# Altium schematic sheet SPI LVDS Peripheral.SchDoc("SPI LVDS Peripheral")
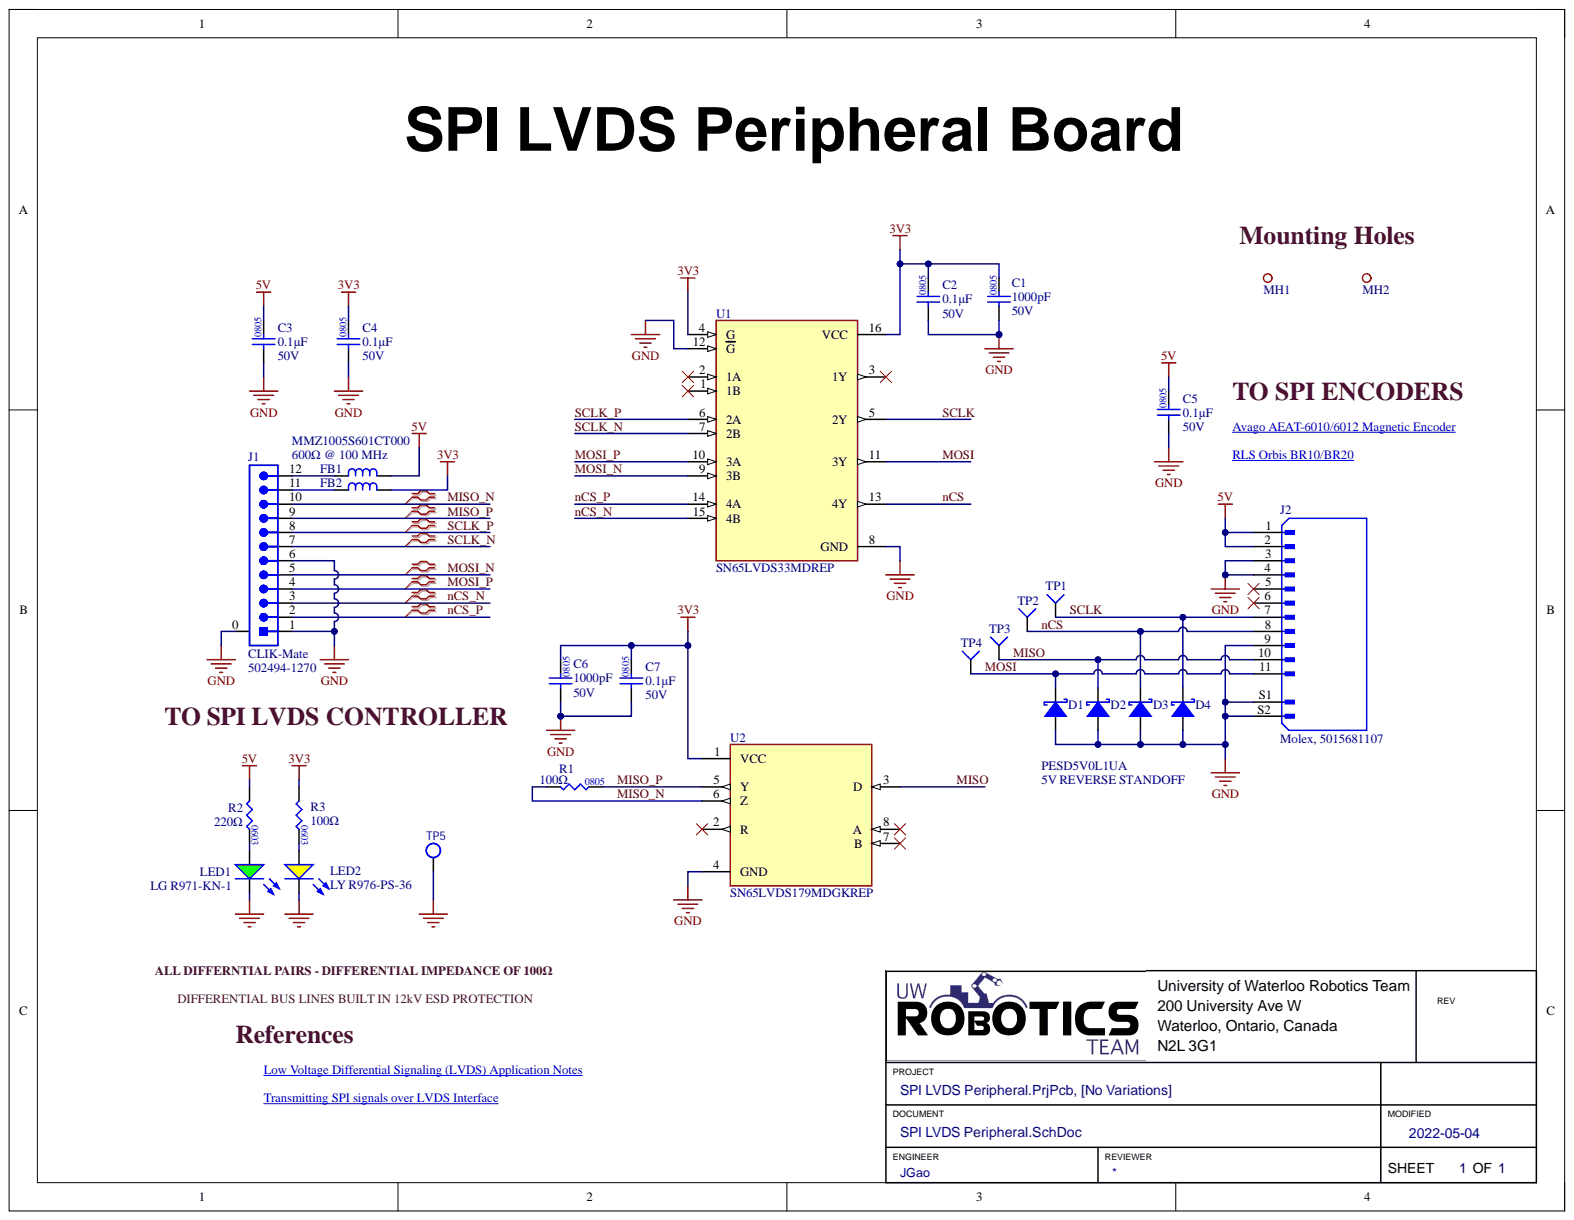
[diagram p0: SPI LVDS Peripheral.SchDoc]

## Parts
C1  value 1000pF  Package/Footprint: 0805  Voltage Rating: 50V  [CAP2012]  (hidden comment: CAP_1000pF_50V_0805)
C2  value 0.1μF  Package/Footprint: 0805  Voltage Rating: 50V  [CAP2012]  (hidden comment: CAP_0.1uF_50V_0805)
C3  value 0.1μF  Package/Footprint: 0805  Voltage Rating: 50V  [CAP2012]  (hidden comment: CAP_0.1uF_50V_0805)
C4  value 0.1μF  Package/Footprint: 0805  Voltage Rating: 50V  [CAP2012]  (hidden comment: CAP_0.1uF_50V_0805)
C5  value 0.1μF  Package/Footprint: 0805  Voltage Rating: 50V  [CAP2012]  (hidden comment: CAP_0.1uF_50V_0805)
C6  value 1000pF  Package/Footprint: 0805  Voltage Rating: 50V  [CAP2012]  (hidden comment: CAP_1000pF_50V_0805)
C7  value 0.1μF  Package/Footprint: 0805  Voltage Rating: 50V  [CAP2012]  (hidden comment: CAP_0.1uF_50V_0805)
D1  TVS_DIODE_UNIDIR_5V  [SOD-323]  (value not drawn)
D2  TVS_DIODE_UNIDIR_5V  [SOD-323]  (value not drawn)
D3  TVS_DIODE_UNIDIR_5V  [SOD-323]  (value not drawn)
D4  TVS_DIODE_UNIDIR_5V  [SOD-323]  (value not drawn)
FB1  Impedance: 600Ω  Impedance @ Frequency: 600Ω @ 100 MHz  Manufacturer Part Number 1: MMZ1005S601CT000  [RES0402]  (hidden comment: FERRITE_BEAD_600OHM_0402)
FB2  FERRITE_BEAD_600OHM_0402  [RES0402]  (value not drawn)
J1  Family: CLIK-Mate  Manufacturer Part Number: 502494-1270  [MOLEX-5024941270]  (hidden comment: CON_12M_SM_RA_2mm)
J2  MP: Molex, 5015681107  [MOLEX-5015681107]  (hidden comment: CON_12M_SM_RA)
LED1  LED_GREEN  [LED_0805_GREEN]  (value not drawn)
LED2  Manufacturer Part Number 1: LY R976-PS-36  [LED_0805_YELLOW]  (hidden comment: LED_YELLOW)
MH1  MOUNTING_HOLE_M3  [MOUNTING_HOLE_3mm]  (value not drawn)  (no BOM)
MH2  MOUNTING_HOLE_M3  [MOUNTING_HOLE_3mm]  (value not drawn)  (no BOM)
R1  value 100Ω  Package/Footprint: 0805  [RES0805]  (hidden comment: RES_100Ω_0805_1%)
R2  value 220Ω  Package/Footprint: 0603  [RES0603]  (hidden comment: RES_220Ω_0603_5%)
R3  value 100Ω  [RES0603]  (hidden comment: RES_100Ω_0603_1%)
TP1  TESTPOINT_1MM_SQUARE  [TESTPOINT_SQUARE_1MM]  (value not drawn)  (no BOM)
TP2  TESTPOINT_1MM_SQUARE  [TESTPOINT_SQUARE_1MM]  (value not drawn)  (no BOM)
TP3  TESTPOINT_1MM_SQUARE  [TESTPOINT_SQUARE_1MM]  (value not drawn)  (no BOM)
TP4  TESTPOINT_1MM_SQUARE  [TESTPOINT_SQUARE_1MM]  (value not drawn)  (no BOM)
TP5  TESTPOINT_LOOP  [TESTPOINT_LOOP]  (value not drawn)
U1  SN65LVDS33MDREP  [SOIC127P600X175-16N]
U2  SN65LVDS179MDGKREP  [SOP65P490X110-8N]

## Nets
3V3: C1.2 C2.2 C4.2 C6.2 C7.2 FB2.1 R3.1 U1.4(G) U1.16(VCC) U2.1(VCC)
5V: C3.2 C5.2 FB1.1 J2.1 J2.2 R2.1
GND: C1.1 C2.1 C3.1 C4.1 C5.1 C6.1 C7.1 D1.1 D2.1 D3.1 D4.1 J1.0 J1.1 J1.6 J2.3 J2.4 J2.9 J2.S1 J2.S2 LED1.2 LED2.2 TP5.1 U1.8(GND) U1.12(G) U2.4(GND)
MISO: D2.2 J2.10 TP3.1 U2.3(D)
MISO_N: J1.10 R1.1 U2.6(Z)
MISO_P: J1.9 R1.2 U2.5(Y)
MOSI: D1.2 J2.11 TP4.1 U1.11(3Y)
MOSI_N: J1.5 U1.9(3B)
MOSI_P: J1.4 U1.10(3A)
nCS: D3.2 J2.8 TP2.1 U1.13(4Y)
nCS_N: J1.3 U1.15(4B)
nCS_P: J1.2 U1.14(4A)
SCLK: D4.2 J2.7 TP1.1 U1.5(2Y)
SCLK_N: J1.7 U1.7(2B)
SCLK_P: J1.8 U1.6(2A)
NetFB1_2: FB1.2 J1.12
NetFB2_2: FB2.2 J1.11
NetLED1_1: LED1.1 R2.2
NetLED2_1: LED2.1 R3.2

Not connected: J2 5 6; U1 1(1B) 2(1A) 3(1Y); U2 2(R) 7(B) 8(A)

## Library data of the parts
C1 C6 = CAP_1000pF_50V_0805: CAP CER 1000pF 50V X7R 0805; Manufacturer 1: Samsung; Manufacturer Part Number 1: CL21B102KBANNNC; Component Kind: Standard; Dielectric: X7R; Mounting Type: SMD; Supplier 1: Digi-Key; Supplier Part Number 1: 1276-1020-1-ND; Library Name: UWRT_Schematic_Library_2020.SchLib
C2-C5 C7 = CAP_0.1uF_50V_0805: CAP CER 0.1UF 50V X7R 0805; Manufacturer 1: KEMET; Manufacturer Part Number 1: C0805C104K5RAC7411; Component Kind: Standard; Dielectric: X7R; LCSC Part #: C49678; Mounting Type: SMD; Supplier 1: Mouser; Supplier Part Number 1: 80-C0805C104K5RACLR; Library Name: UWRT_Schematic_Library_2020.SchLib
D1-D4 = TVS_DIODE_UNIDIR_5V: TVS DIODE 5VWM 12VC SOD-323-2; Manufacturer 1: Nexperia; Manufacturer Part Number 1: PESD5V0L1UA; Clamping Voltage-Max: 12V; Min Breakdown Voltage: 6.8 V; Component Kind: Standard; Supplier 1: Mouser; Supplier Part Number 1: 771-PESD5V0L1UA115; Library Name: UWRT_Schematic_Library_2020.SchLib
FB1 = FERRITE_BEAD_600OHM_0402: FIXED IND 1UH 3.8A 33 MOHM SMD; Manufacturer 1: TDK Corporation; Current Rating (Max): 300mA; DC Resistance (DCR) (Max): 520mΩ; Component Kind: Standard; Mounting Type: SMD; Package: 0402; Package/Footprint: SMD; Supplier 1: Digi-Key; Supplier Part Number 1: 445-2162-1-ND; Library Name: UWRT_Schematic_Library_2020.SchLib
FB2 = FERRITE_BEAD_600OHM_0402: FIXED IND 1UH 3.8A 33 MOHM SMD; Manufacturer 1: TDK Corporation; Manufacturer Part Number 1: MMZ1005S601CT000; Current Rating (Max): 300mA; DC Resistance (DCR) (Max): 520mΩ; Impedance: 600Ω; Impedance @ Frequency: 600Ω @ 100 MHz; Component Kind: Standard; Mounting Type: SMD; Package: 0402; Package/Footprint: SMD; Supplier 1: Digi-Key; Supplier Part Number 1: 445-2162-1-ND; Library Name: UWRT_Schematic_Library_2020.SchLib
J1 = CON_12M_SM_RA_2mm: 2.00mm Pitch CLIK-Mate Wire-to-Board PCB Receptacle, Single Row, Right-Angle, 12 Circuits; Manufacturer: Molex; Contact Resistance: 20mR; Current Rating: 3A; Glow Wire Compliant: Non-compliant; Insulation Resistance: 1GR; Mating Cycles: 30; Max Voltage Rating (AC): 250V; Number of Circuits: 12; Pitch: 2mm; Category: Headers and Wire Housings; Color: Natural,White; Component Kind: Standard; Connector Type: Receptacle; Contact Gender: Female; Contact Material: Copper Alloy; Contact Plating: Tin; Contact Type: Center Strip Contact; Depth: 6.85mm; Fastening Type: Latch; Flammability Rating: UL94 V-0; Gender: Receptacle; Housing Color: Natural; Housing Material: PBT; Lead Pitch: 2mm; Length: 28mm; Material: Polybutylene; Max Operating Temperature: 85°C; Max Voltage Rating (DC): 250V; Min Operating Temperature: -25°C; Mount: Surface Mount; Number of Contacts: 12; Number of Positions: 12; Number of Rows: 1; Orientation: Right Angle; Package Quantity: 950; Packaging: Tape and Reel; Pricing 1: 950=0.62780, 1900=0.61060, 3800=0.56580, 5700=0.53600, 7600=0.52000 (USD); Radiation Hardening: No; REACH SVHC: Unknown; RoHS: Yes; Series: 502494; Stock 1: 1900; Supplier 1: Mouser; Supplier Part Number 1: 502494-1270; Termination: Solder; Voltage Rating (AC): 250V; Library Name: UWRT_Schematic_Library_2020.SchLib
J2 = CON_11M_SM_RA: CONN HEADER SMD RIGHT ANGLE 1MM PITCH; Availability: Unavailable; Component Kind: Standard; Package: None; Price: None; Supplier 1: Digi-Key; Supplier Part Number 1: WM7902CT-ND; Library Name: UWRT_Schematic_Library_2020.SchLib
LED1 = LED_GREEN: LED GREEN DIFFUSED 0805 SMD; Manufacturer 1: OSRAM; Manufacturer Part Number 1: LG R971-KN-1; Forward Voltage Drop: 2.2V; Package/Footprint: 0805; Component Kind: Standard; Mounting Type: SMD; Supplier 1: Digi-Key; Supplier Part Number 1: 475-1410-1-ND; Library Name: UWRT_Schematic_Library_2020.SchLib
LED2 = LED_YELLOW: LED YELLOW DIFFUSED 0805 SMD; Manufacturer 1: OSRAM; Forward Voltage Drop: 2.2V; Component Kind: Standard; Package: 0805 (2012 Metric); Supplier 1: Digi-Key; Supplier Part Number 1: 475-2560-1-ND; Library Name: UWRT_Schematic_Library_2020.SchLib
R1 = RES_100Ω_0805_1%: RES 100 OHM 1% 1/8W 0805; Manufacturer 1: ROHM Semiconductor; Manufacturer Part Number 1: SFR10EZPF1000; Component Kind: Standard; LCSC Part #: C1790; Mounting Type: SMD; Supplier 1: Mouser; Supplier Part Number 1: 755-SFR10EZPF1000; Library Name: UWRT_Schematic_Library_2020.SchLib
R2 = RES_220Ω_0603_5%: RES 220 OHM 5% 1/10W 0603; Manufacturer 1: Yageo; Manufacturer Part Number 1: AC0603JR-07220RL; Component Kind: Standard; Supplier 1: Digi-Key; Supplier Part Number 1: YAG3652CT-ND; Library Name: UWRT_Schematic_Library_2020.SchLib
R3 = RES_100Ω_0603_1%: RES 100 OHM 1% 1/10W 0603; Manufacturer 1: Yageo; Manufacturer Part Number 1: RC0603FR-07100RL; Component Kind: Standard; Supplier 1: Digi-Key; Supplier Part Number 1: 311-100HRCT-ND; Library Name: UWRT_Schematic_Library_2020.SchLib
TP1-TP4 = TESTPOINT_1MM_SQUARE: TESTPOINT 1MM SQUARE; Library Name: UWRT_Schematic_Library_2020.SchLib
TP5 = TESTPOINT_LOOP: TESTPOINT THRUHOLE; Manufacturer 1: Keystone Electronics; Manufacturer Part Number 1: 5002; Package/Footprint: TH; Component Kind: Standard; Mounting Type: TH; Supplier 1: Digi-Key; Supplier Part Number 1: 36-5002-ND; Library Name: UWRT_Schematic_Library_2020.SchLib
U1 = SN65LVDS33MDREP: IC RECEIVER 0/4 16SOIC; Availability: Unavailable; Component Kind: Standard; MP: SN65LVDS33MDREP; Package: None; Price: None; Supplier 1: Digi-Key; Supplier Part Number 1: 296-19498-1-ND; Library Name: UWRT_Schematic_Library_2020.SchLib
U2 = SN65LVDS179MDGKREP: Enhanced Product High-Speed Differential Line Drivers And Receivers 8-VSSOP -55 to 125; MF: Texas Instruments; Component Kind: Standard; Digi-Key_Part_Number: 296-22243-1-ND; MP: SN65LVDS179MDGKREP; Package: VSSOP-8 Texas Instruments; Purchase-URL: https://pricing.snapeda.com/search/part/SN65LVDS179MDGKREP/?ref=eda; SnapEDA_Link: https://www.snapeda.com/parts/SN65LVDS179MDGKREP/Texas%20Instruments/view-part/383554/?ref=snap; Supplier 1: Digi-Key; Supplier Part Number 1: 296-22243-1-ND; Library Name: UWRT_Schematic_Library_2020.SchLib
MH1 MH2 = MOUNTING_HOLE_M3: Description: MOUNTING_HOLE_M3; Library Name: UWRT_Schematic_Library_2020.SchLib
